# Altium schematic sheet pt100.SchDoc(pt100)
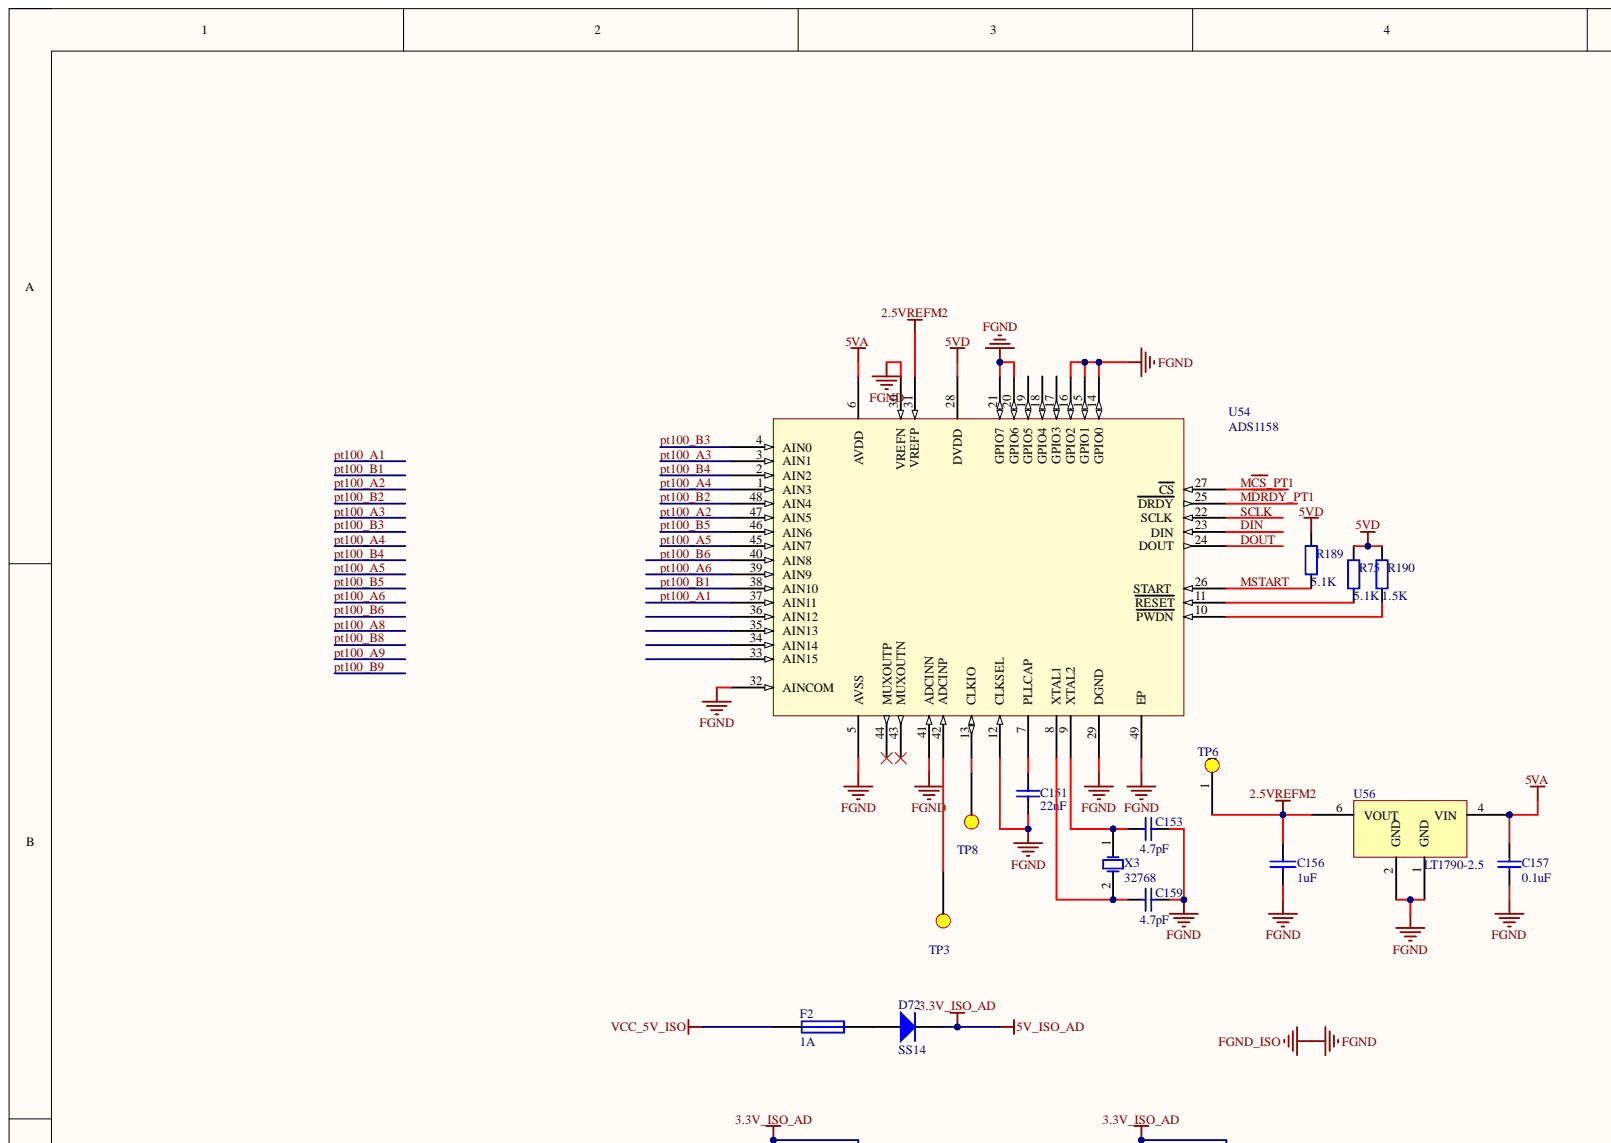
[diagram p0: pt100.SchDoc, top left]
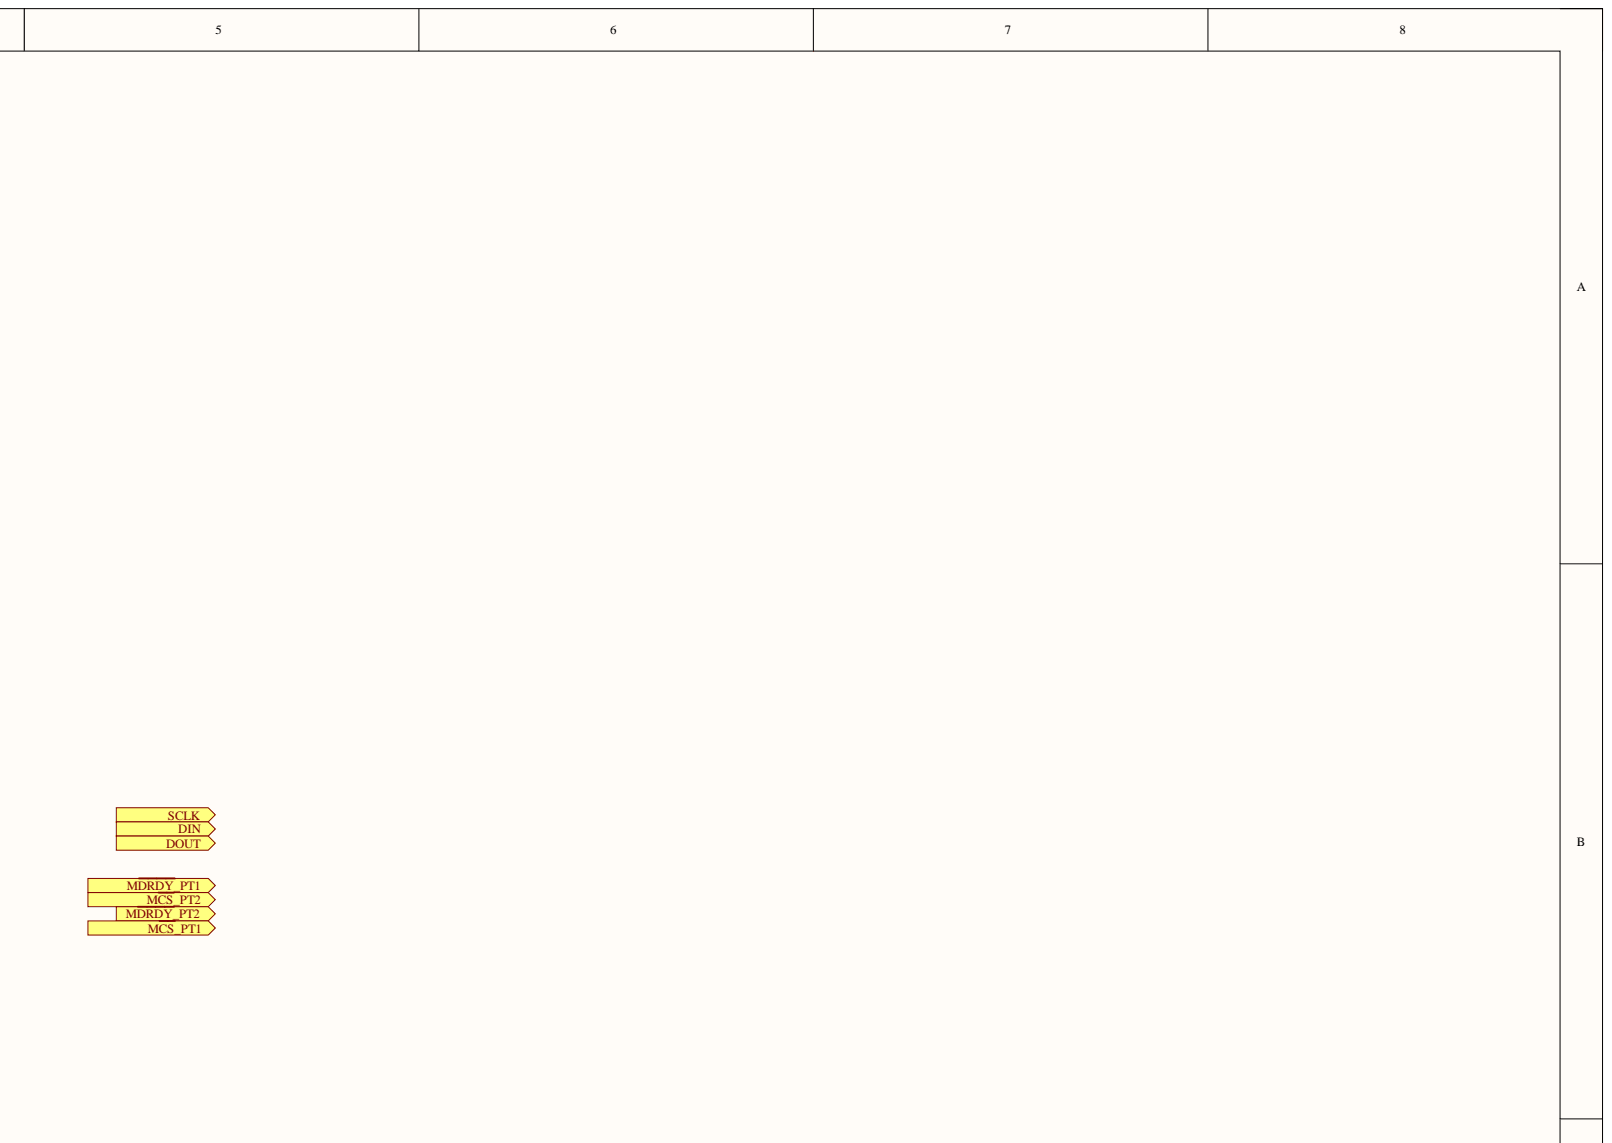
[diagram p1: pt100.SchDoc, top right]
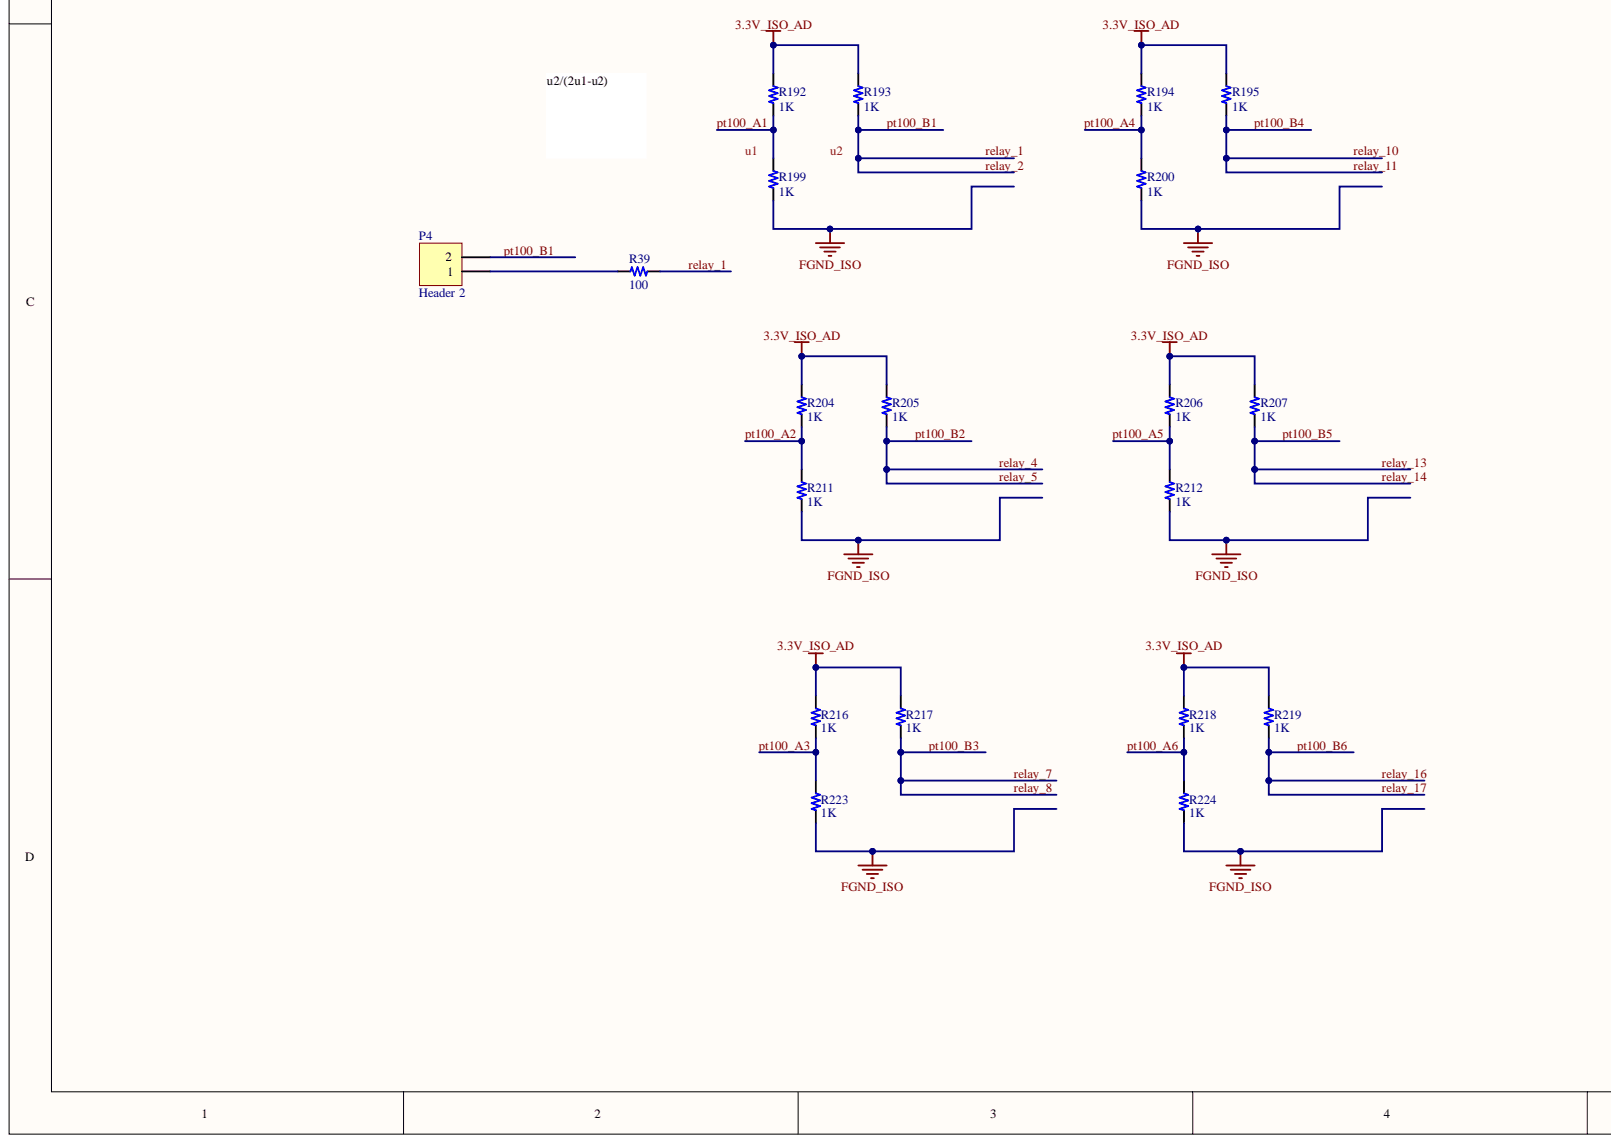
[diagram p2: pt100.SchDoc, bottom left]
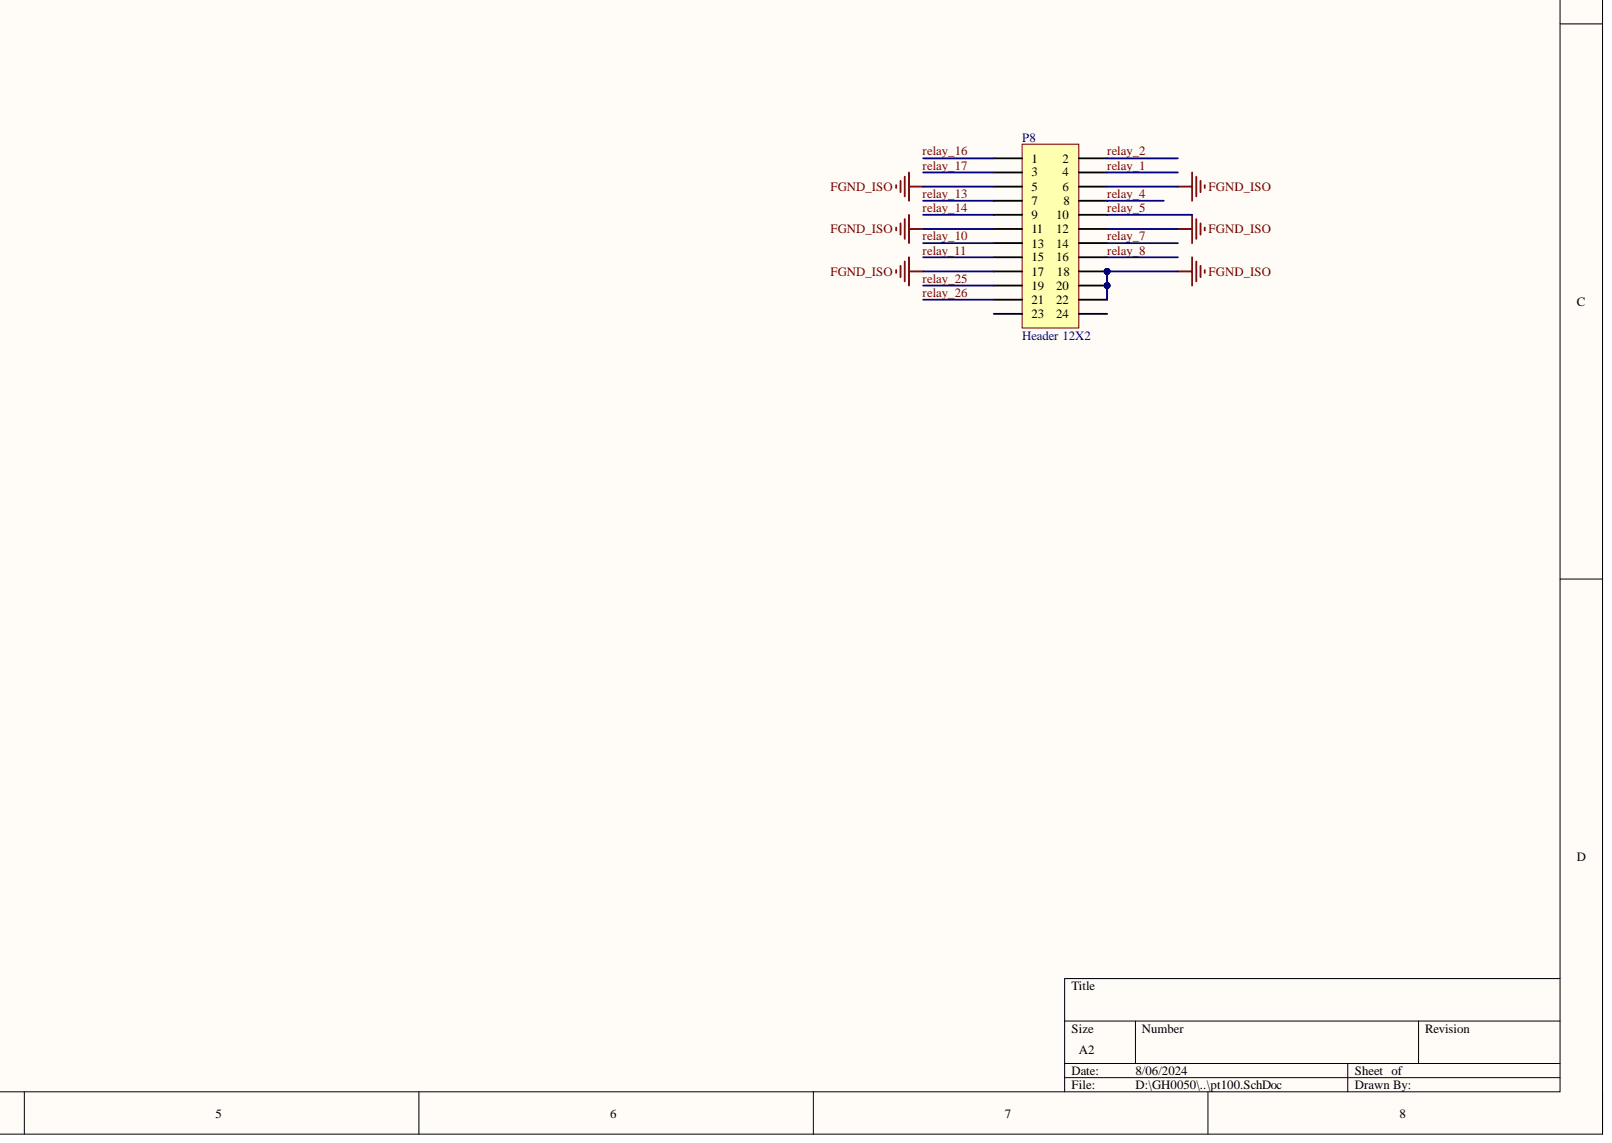
[diagram p3: pt100.SchDoc, bottom right]

## Parts
C151  22nF  [0805C]
C153  4.7pF  [0603C]
C156  1uF  [0603C]
C157  0.1uF  [0603C]
C159  4.7pF  [0603C]
D72  SS14  [DO-214AC]
F2  1A  [0805r]
P4  Header 2  [HDR1X2]  (no BOM)
P8  Header 12X2  [XH2.54_2x12]
R39  100  [0603R]
R75  5.1K  [0603R]
R189  5.1K  [0603R]
R190  1.5K  [0603R]
R192  1K  [0603R]
R193  1K  [0603R]
R194  1K  [0603R]
R195  1K  [0603R]
R199  1K  [0603R]
R200  1K  [0603R]
R204  1K  [0603R]
R205  1K  [0603R]
R206  1K  [0603R]
R207  1K  [0603R]
R211  1K  [0603R]
R212  1K  [0603R]
R216  1K  [0603R]
R217  1K  [0603R]
R218  1K  [0603R]
R219  1K  [0603R]
R223  1K  [0603R]
R224  1K  [0603R]
TP3  TEST_POINT  [TPD]  (value not drawn)
TP6  TEST_POINT  [TPD]  (value not drawn)
TP8  TEST_POINT  [TPD]  (value not drawn)
U54  ADS1158  [S-PVFQN-N48]
U56  LT1790-2.5  [SOT23-6]
X3  32768  [A]

## Ports
DIN, DOUT, M~{CS}_PT1, M~{CS}_PT2, M~{DRDY}_PT1, M~{DRDY}_PT2, SCLK

## Nets
2.5VREFM2: C156.1 TP6.1 U54.31(VREFP) U56.6(VOUT)
3.3V_ISO_AD: D72.2 R192.2 R193.2 R194.2 R195.2 R204.2 R205.2 R206.2 R207.2 R216.2 R217.2 R218.2 R219.2
5VA: C157.2 U54.6(AVDD) U56.4(VIN)
5VD: R75.1 R189.1 R190.1 U54.28(DVDD)
FGND: C151.2 C153.2 C156.2 C157.1 C159.2 P8.5 P8.6 P8.11 P8.12 P8.17 P8.18 P8.20 P8.22 R199.1 R200.1 R211.1 R212.1 R223.1 R224.1 U54.5(AVSS) U54.12(CLKSEL) U54.14(GPIO0) U54.15(GPIO1) U54.16(GPIO2) U54.20(GPIO6) U54.21(GPIO7) U54.29(DGND) U54.30(VREFN) U54.32(AINCOM) U54.41(ADCINN) U54.49(EP) U56.1(GND) U56.2(GND)
VCC_5V_ISO: F2.1
DIN: U54.23(DIN)
DOUT: U54.24(DOUT)
M~{CS}_PT1: U54.27(CS)
M~{DRDY}_PT1: U54.25(DRDY)
MSTART: R189.2 U54.26(START)
pt100_A1: R192.1 R199.2 U54.37(AIN11)
pt100_A2: R204.1 R211.2 U54.47(AIN5)
pt100_A3: R216.1 R223.2 U54.3(AIN1)
pt100_A4: R194.1 R200.2 U54.1(AIN3)
pt100_A5: R206.1 R212.2 U54.45(AIN7)
pt100_A6: R218.1 R224.2 U54.39(AIN9)
pt100_B1: P4.2 P8.2 P8.4 R39.1 R193.1 U54.38(AIN10)
pt100_B2: P8.8 P8.10 R205.1 U54.48(AIN4)
pt100_B3: P8.14 P8.16 R217.1 U54.4(AIN0)
pt100_B4: P8.13 P8.15 R195.1 U54.2(AIN2)
pt100_B5: P8.7 P8.9 R207.1 U54.46(AIN6)
pt100_B6: P8.1 P8.3 R219.1 U54.40(AIN8)
relay_25: P8.19
relay_26: P8.21
SCLK: U54.22(SCLK)
NetC151_1: C151.1 U54.7(PLLCAP)
NetC153_1: C153.1 U54.9(XTAL2) X3.1
NetC159_1: C159.1 U54.8(XTAL1) X3.2
NetD72_1: D72.1 F2.2
NetP4_1: P4.1 R39.2
NetR75_2: R75.2 U54.11(RESET)
NetR190_2: R190.2 U54.10(PWDN)
NetTP3_1: TP3.1 U54.42(ADCINP)
NetTP8_1: TP8.1 U54.13(CLKIO)
N00005: ; port M~{CS}_PT2
N00007: ; port M~{CS}_PT1
N00009: ; port M~{DRDY}_PT2
N00015: ; port SCLK
N00025: ; port DOUT
N00031: ; port DIN
N00035: ; port M~{DRDY}_PT1
pt100_A8:
pt100_A9:
pt100_B8:
pt100_B9:

Not connected: P8 23 24; U54 17(GPIO3) 18(GPIO4) 19(GPIO5) 33(AIN15) 34(AIN14) 35(AIN13) 36(AIN12) 43(MUXOUTN) 44(MUXOUTP)

## Library data of the parts
C151 C153 C157 = Cap: Capacitor; Component Kind: Standard; LatestRevisionDate: 17-Jul-2002; LatestRevisionNote: Re-released for DXP Platform.; Library Name: Miscellaneous Devices.IntLib; PackageDescription: Radial Cap, Thru-Hole; 2 Leads; 0.3 in Pin Spacing; PackageReference: RAD-0.3; Published: 8-Jun-2000; Signal Integrity: Cap; Simulation: CAP; Publisher: Altium Limited
  C157: Value: 0.1uF
C156 = CAP: Capacitor; Component Kind: Standard
C159 = Cap: Capacitor; Value: 6.8pF; Component Kind: Standard; LatestRevisionDate: 17-Jul-2002; LatestRevisionNote: Re-released for DXP Platform.; Library Name: Miscellaneous Devices.IntLib; PackageDescription: Radial Cap, Thru-Hole; 2 Leads; 0.3 in Pin Spacing; PackageReference: RAD-0.3; Published: 8-Jun-2000; Signal Integrity: Cap; Simulation: CAP; Publisher: Altium Limited
D72 = Diode: Default Diode; Code_JEDEC: DO-214-AB; Component Kind: Standard; LatestRevisionDate: 17-Jul-2002; LatestRevisionNote: Re-released for DXP Platform.; Library Name: Miscellaneous Devices.IntLib; PackageReference: SMC; PackageVersion: Sep-1996; Published: 8-Jun-2000; Signal Integrity: Diode; Simulation: DIODE; Publisher: Altium Limited
F2 = Fuse 1: Fuse; Component Kind: Standard; LatestRevisionDate: 17-Jul-2002; LatestRevisionNote: Re-released for DXP Platform.; Library Name: Miscellaneous Devices.IntLib; PackageDescription: Thru-Hole; 2 Leads; PackageReference: PIN-W2/E2.8; Published: 8-Jun-2000; Simulation: FUSE; Publisher: Altium Limited
P4 = Header 2: Header, 2-Pin; LatestRevisionDate: 17-Jul-2002; LatestRevisionNote: Re-released for DXP Platform.; Library Name: Miscellaneous Connectors.IntLib; Signal Integrity: Connector; Publisher: Altium Limited
P8 = Header 12X2: Header, 12-Pin, Dual row; Component Kind: Standard; LatestRevisionDate: 17-Jul-2002; LatestRevisionNote: Re-released for DXP Platform.; Library Name: Miscellaneous Connectors.IntLib; Signal Integrity: Connector; Publisher: Altium Limited
R39 R192-R195 R199 R200 R204-R207 R211 R212 R216-R219 R223 R224 = R: Component Kind: Standard; Description: R; Library Name: JRJ_1.SCHLIB
R75 R189 R190 = Res0805M: Component Kind: Standard; Library Name: MQlibrary.IntLib
U54 = ADS1158: 16-Channel, 16-Bit Analog-to-Digital Converter; Component Kind: Standard; Library Name: Schlib.SchLib
U56 = LT1790-2.5: 2.5V Micropower SOT-23  Low Dropout Reference; Component Kind: Standard; Library Name: Schlib.SchLib
X3 = XTAL: Crystal Oscillator; Component Kind: Standard; LatestRevisionDate: 17-Jul-2002; LatestRevisionNote: Re-released for DXP Platform.; Library Name: Miscellaneous Devices.IntLib; PackageReference: R38; Published: 8-Jun-2000; Simulation: XTAL; Publisher: Altium Limited
TP3 TP6 TP8 = TEST_POINT: Component Kind: Standard; Library Name: 基带芯片OEM板_V1P0_张猛_20120921.SCHLIB
